# Altium schematic sheet Microphone-Boards_V1.0_SD18OB261.SchDoc
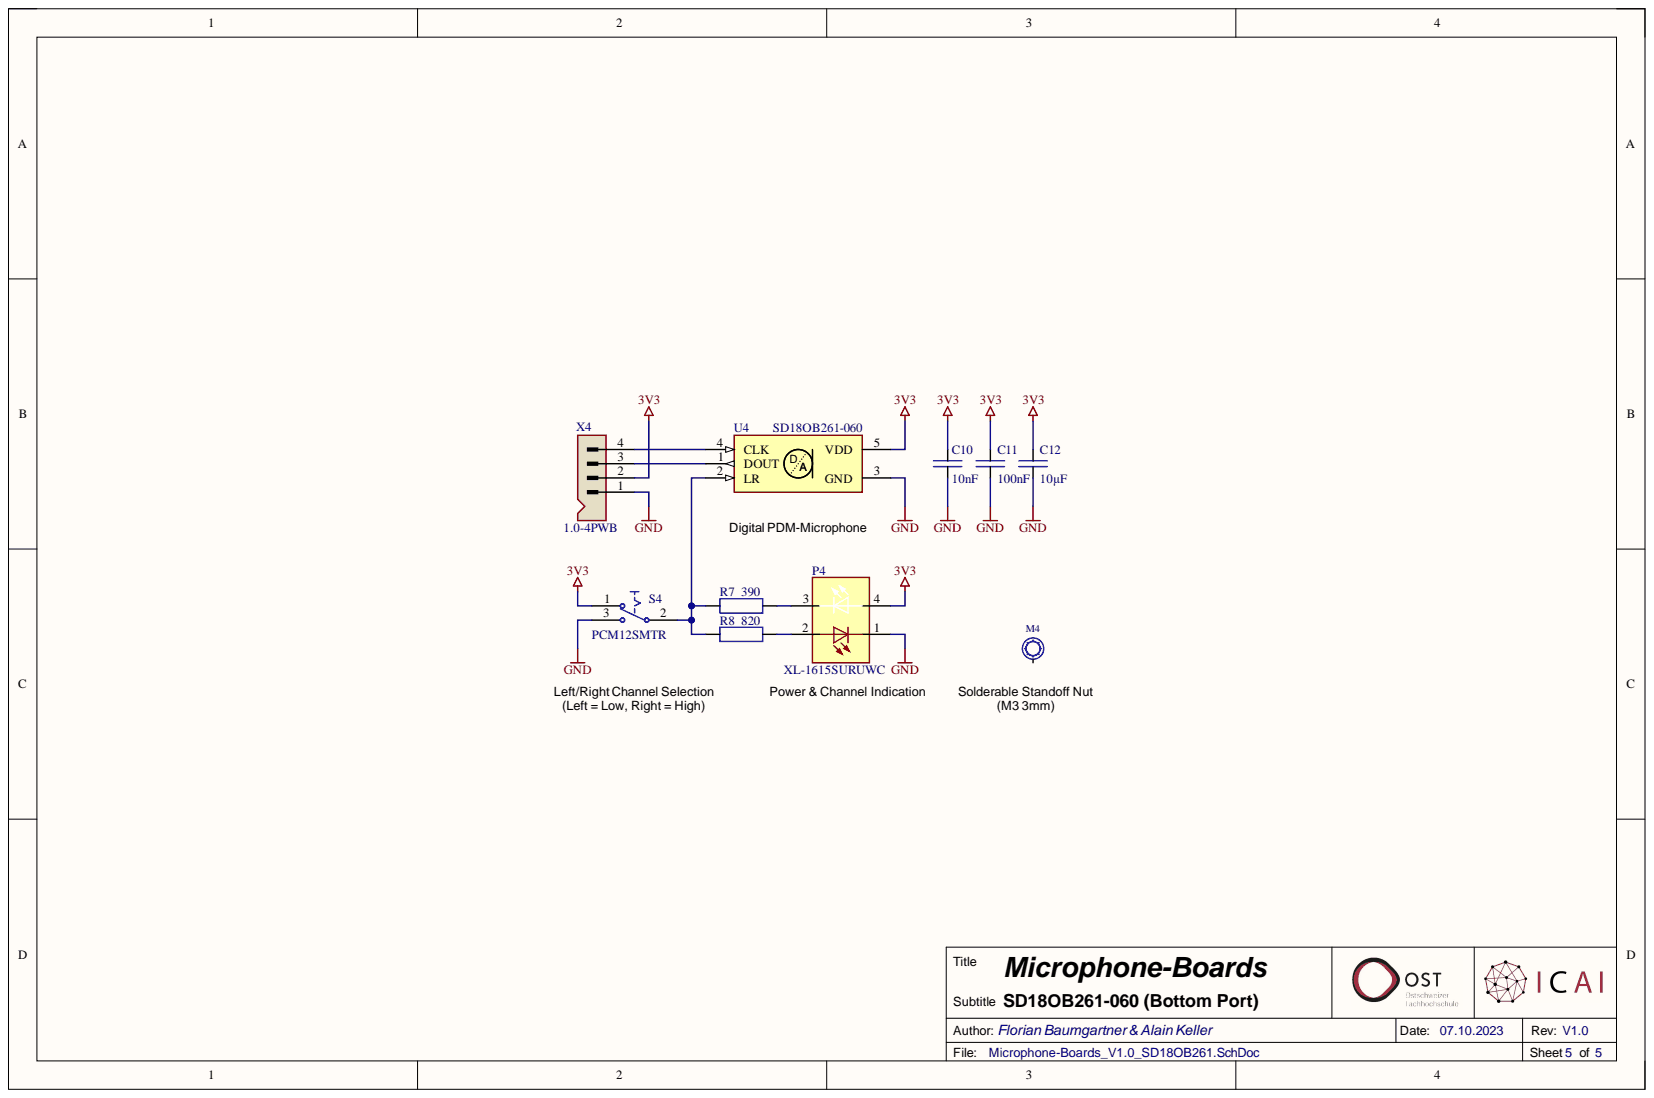
[diagram p0: Microphone-Boards_V1.0_SD18OB261.SchDoc]

## Parts
C10  10nF  [SMD Keramikkondensator 0603]
C11  100nF  [SMD Keramikkondensator 0603]
C12  10µF  [SMD Keramikkondensator 1206]
M4  SMTSO-M3-3ET  [SMD Solder-Nut (M3 3mm)]  (value not drawn)
P4  XL-1615SURUWC  [SMD LED 1210]
R7  390  [SMD Widerstand 0603]
R8  820  [SMD Widerstand 0603]
S4  PCM12SMTR  [SMD Switch SPDT (PCM12SMTR)]
U4  SD18OB261-060  [SMD Microphone (MP34DT06JTR)]
X4  1.0-4PWB  [SMD Connector (SM04B-SRSS-TB)]

## Nets
3V3: C10.2 C11.2 C12.2 P4.4 S4.1 U4.5(VDD) X4.2
GND: C10.1 C11.1 C12.1 P4.1 S4.3 U4.3(GND) X4.1
NetP4_2: P4.2 R8.2
NetP4_3: P4.3 R7.2
NetR7_1: R7.1 R8.1 S4.2 U4.2(LR)
NetU4_1: U4.1(DOUT) X4.3
NetU4_4: U4.4(CLK) X4.4

Not connected: M4 1

## Library data of the parts
C10 = C-CAPACITOR: CAP-MK; Component Description: Multilayer Ceramic Capacitors; Component Value: 10nF 50V; Component Kind: Standard; Digikey: 445-5662-1-ND; Farnell: 2346966; JLC-Parts: C57112; Library Name: AudioAmp.SCHLIB; Mouser: 77-VJ0603V103ZXAPBC; Unit Price: 0.01
C11 = E6 - 100nF: SMD Ceramic Capacitor E6; Component Description: Multilayer Ceramic Capacitors MLCC; Component Value: 100nF 50V; Component Kind: Standard; ComponentLink1Description: Datasheet; ComponentLink1URL: https://datasheet.lcsc.com/lcsc/1809301912_YAGEO-CC0603KRX7R9BB104_C14663.pdf; Digikey: 445-6899-1-ND; Farnell: 2210822; JLC-Parts: C14663; Library Name: Ceramic Capacitors-E6.SchLib; Mouser: 810-CGA2B3X7R1H104K; Unit Price: 0.01
C12 = E6 - 10uF: SMD Ceramic Capacitor E6; Component Description: Multilayer Ceramic Capacitors MLCC; Component Value: 10µF 50V; Component Kind: Standard; ComponentLink1Description: Datasheet; ComponentLink1URL: https://datasheet.lcsc.com/lcsc/1810191219_Samsung-Electro-Mechanics-CL10A106KP8NNNC_C19702.pdf; Digikey: 587-3258-1-ND; Farnell: 2113070; JLC-Parts: C13585; Library Name: Ceramic Capacitors-E6.SchLib; Mouser: 963-LMK107BBJ106MALT; Unit Price: 0.01
M4 = M-Spacer_M3_3mm: Solderable Standoff Nut; Component Value: M3 3mm; Component Description: Solderable Standoff Nut; Component Kind: Standard; ComponentLink1Description: Datasheet; ComponentLink1URL: https://datasheet.lcsc.com/lcsc/2112061830_Sinhoo-SMTSO3030CTJ_C2915308.pdf; JLC-Parts: C2915308; Mouser: 153-SMTSO-M3-3ET; Unit Price: 0.05; Library Name: Velotour_2022.SCHLIB
P4 = P-XL-1615SURUWC: LED Red/White; Stückpreis: 0.02; Bauteilwert: XL-1615SURUWC; Beschreibung: LED Red/White; Component Kind: Standard; ComponentLink1Description: Datasheet; ComponentLink1URL: https://datasheet.lcsc.com/lcsc/2211030000_XINGLIGHT-XL-1615SURUWC_C965837.pdf; JLC-Parts: C965837; Library Name: Microphone-Boards.SCHLIB
R7 = R-RESISTOR: SMD-Widerstand 1/4W; Component Description: Thick Film Chip Resistor; Component Value: 390 (75V, 100mW, 1%); Component Kind: Standard; Digikey: P390HCT-ND; Farnell: 2303111; JLC-Parts: C23151; Mouser: 667-ERJ-3EKF3900V; Unit Price: 0.06; Library Name: Backplane_1.SCHLIB
R8 = R-RESISTOR: SMD-Widerstand 1/4W; Component Description: Thick Film Chip Resistor; Component Value: 820 (75V, 100mW, 1%); Component Kind: Standard; Digikey: P820HCT-ND; Farnell: 2303136; JLC-Parts: C23253; Mouser: 667-ERJ-3EKF8200V; Unit Price: 0.06; Library Name: Backplane_1.SCHLIB
S4 = S-PCM12SMTR: Miniature Slide Switch; aliExpress: 33002042977; Bauteilwert: PCM12SMTR (SPDT); Stückpreis: 0.77; Beschreibung: Miniature Slide Switch; Component Kind: Standard; Digikey: 401-2016-1-ND; Farnell: 9575146; Library Name: Custom ST-Link.SchLib; Mouser: 611-PCM12SMTR
U4 = U-SD18OB261-060: Digital PDM MEMS Microphone (Bottom Port); Component Description: Digital PDM MEMS Microphone (Bottom Port); Component Kind: Standard; Component Value: SD18OB261-060; ComponentLink1Description: Datasheet; ComponentLink1URL: https://datasheet.lcsc.com/lcsc/2208241200_Goertek-SD18OB261-060_C2895290.pdf; Digikey: 4719-SD18OB261-050DKR-ND; JLC-Parts: C2895290; Library Name: Microphone-Boards.SCHLIB; Unit Price: 0.61
X4 = X-Stiftleiste (4-Fach): Wire To Board Connector (1x4P 1.0mm); Component Description: Wire To Board Connector (1x4P 1.0mm); Component Kind: Standard; Component Value: 1.0-4PWB; Digikey: 455-SM04B-SRSS-TBCT-ND; Farnell: 1830839; JLC-Parts: C2905006; Unit Price: 0.03; Library Name: Audio-Beamformer.SCHLIB
